# Altium schematic sheet LSM_MACININO_00.SchDoc(LSM_MACININO_00)
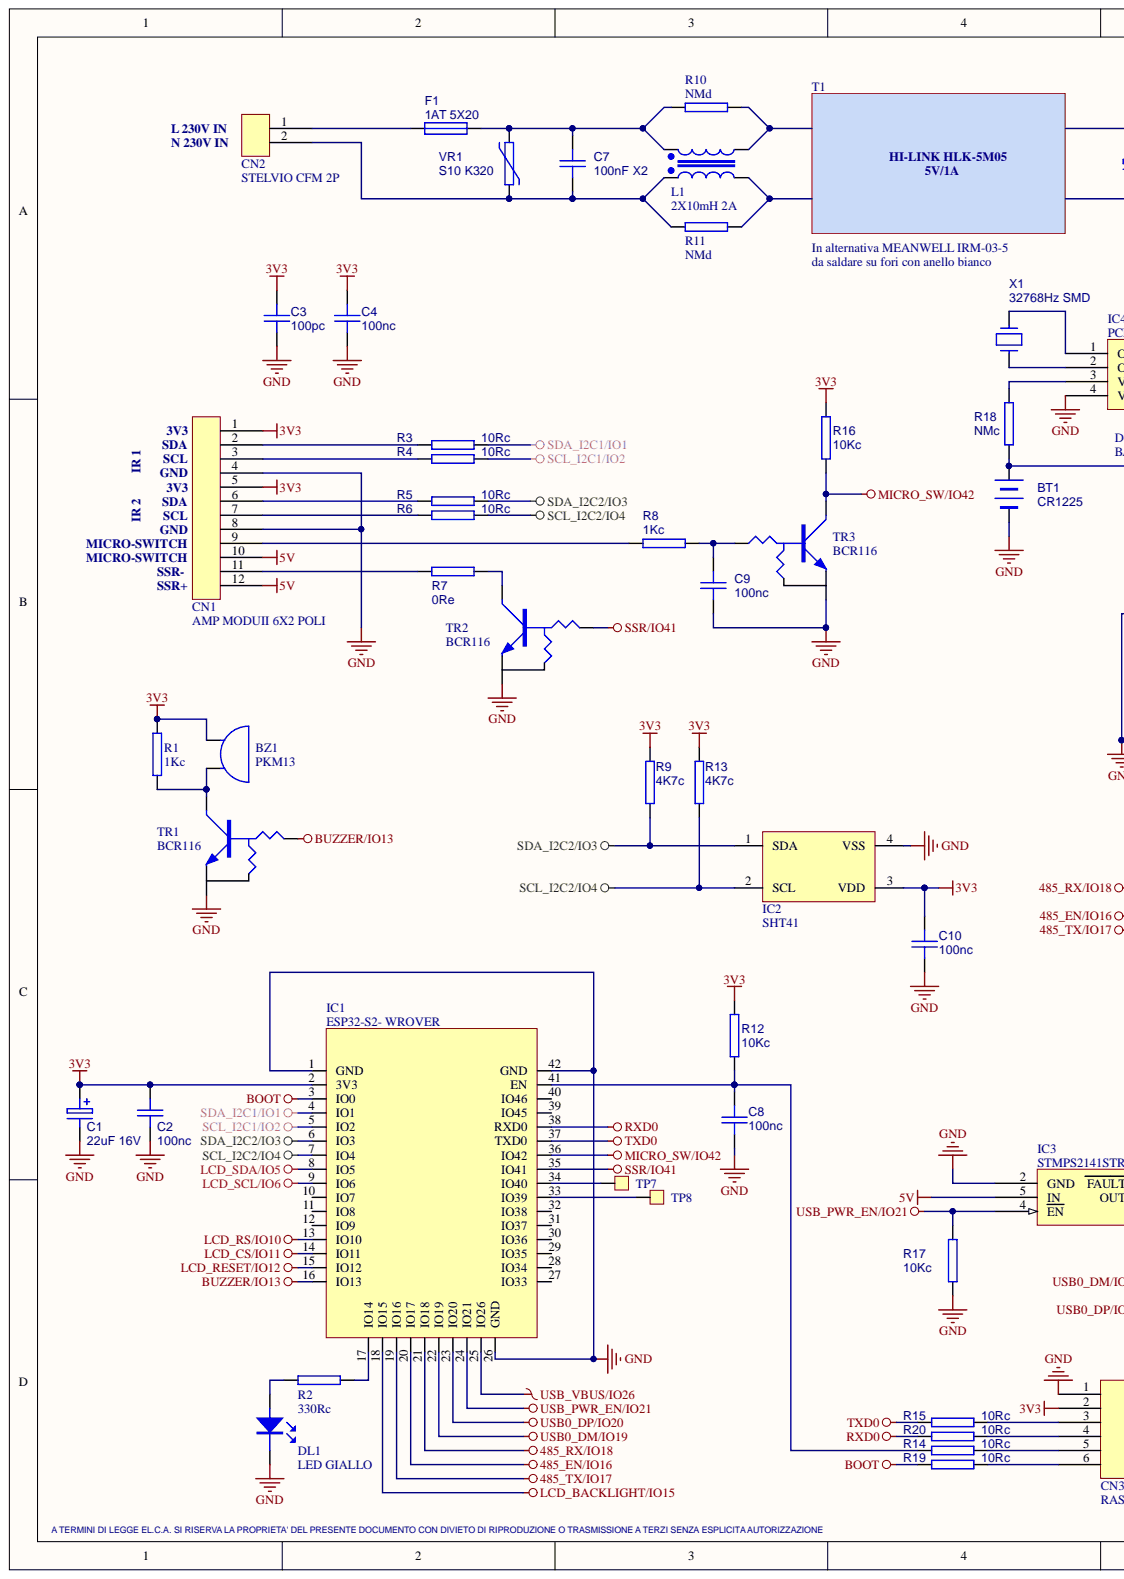
[diagram p0: LSM_MACININO_00.SchDoc, left]
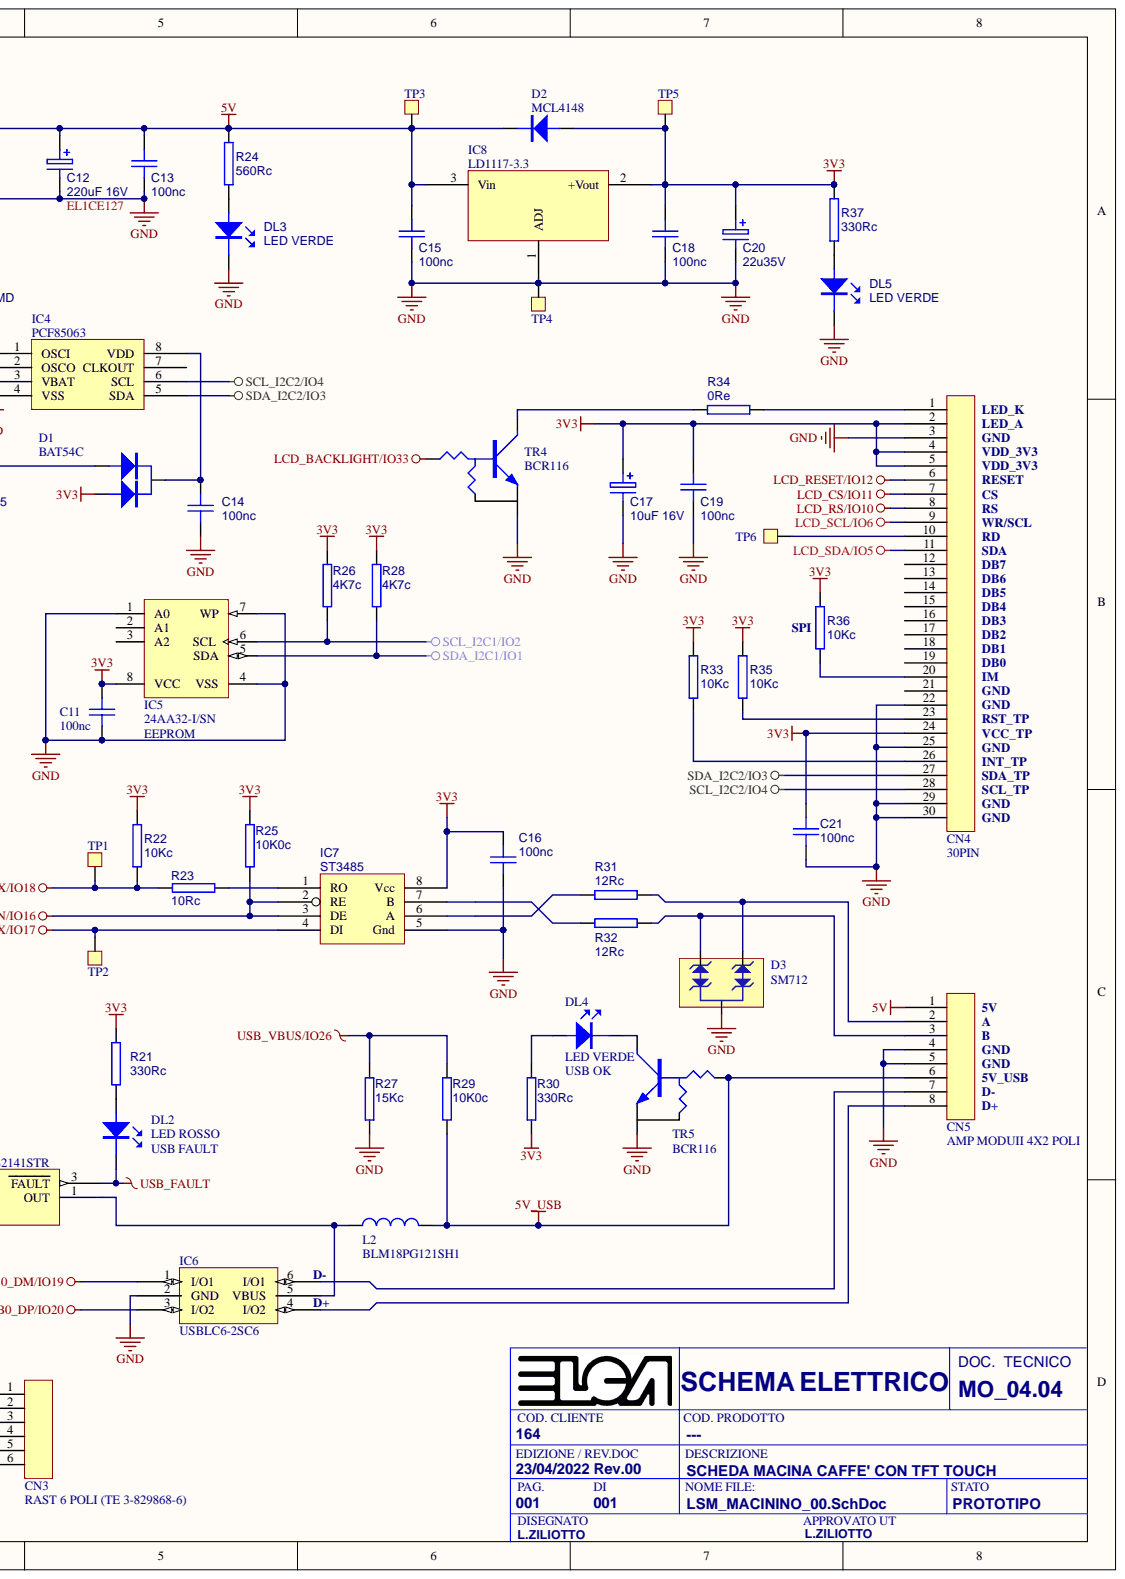
[diagram p1: LSM_MACININO_00.SchDoc, right]

## Parts
BT1  CR1225  [PORTABATTERIA 1225]
BZ1  PKM13  [PKM13]
C1  22uF 16V  [CAP50X]
C2  100nc  [0603]
C3  100pc  [0603]
C4  100nc  [0603]
C7  100nF X2  [12,7X5,5P10,16]
C8  100nc  [0603]
C9  100nc  [0603]
C10  100nc  [0603]
C11  100nc  [0603]
C12  220uF 16V  [D6,6P2,54]
C13  100nc  [0603]
C14  100nc  [0603]
C15  100nc  [0603]
C16  100nc  [0603]
C17  10uF 16V  [CAP40X]
C18  100nc  [0603]
C19  100nc  [0603]
C20  22u35V  [CAP50X]
C21  100nc  [0603]
CN1  AMP MODUII 6X2 POLI  [AMPMODUII-2x6]
CN2  STELVIO CFM 2P  [CFM P.5,08/V 2V]
CN3  RAST 6 POLI (TE 3-829868-6)  [FLY6]
CN4  30PIN  [FFC/FPC 30V/P0,5]
CN5  AMP MODUII 4X2 POLI  [AMPMODUII-2x4]
D1  BAT54C  [SOT-23]
D2  MCL4148  [MICRO MELF]
D3  SM712  [SOT-23]
DL1  LED GIALLO  [L0603]
DL2  LED ROSSO  [L0603]
DL3  LED VERDE  [L0603]
DL4  LED VERDE  [L0603]
DL5  LED VERDE  [L0603]
F1  1AT 5X20  [MICRO-FUSIBILE]
IC1  ESP32-S2- WROVER  [ESP32-S2-WROVER]
IC2  SHT41  [DFN_SHT41]
IC3  STMPS2141STR  [SOT-23-5]
IC4  PCF85063  [SOT1069]
IC5  24AA32-I/SN  ComponentLink1Description: EEPROM  [SO-8]
IC6  USBLC6-2SC6  [SOT-23-6]
IC7  ST3485  [SO-8]
IC8  LD1117-3.3  [SOT-223]
L1  2X10mH 2A  [IND. TENGDA TDS1515]
L2  BLM18PG121SH1  [0603]
R1  1Kc  [0603]
R2  330Rc  [0603]
R3  10Rc  [0603]
R4  10Rc  [0603]
R5  10Rc  [0603]
R6  10Rc  [0603]
R7  0Re  [1210]
R8  1Kc  [0603]
R9  4K7c  [0603]
R10  NMd  [0805]
R11  NMd  [0805]
R12  10Kc  [0603]
R13  4K7c  [0603]
R14  10Rc  [0603]
R15  10Rc  [0603]
R16  10Kc  [0603]
R17  10Kc  [0603]
R18  NMc  [0603]
R19  10Rc  [0603]
R20  10Rc  [0603]
R21  330Rc  [0603]
R22  10Kc  [0603]
R23  10Rc  [0603]
R24  560Rc  [0603]
R25  10K0c  [0603]
R26  4K7c  [0603]
R27  15Kc  [0603]
R28  4K7c  [0603]
R29  10K0c  [0603]
R30  330Rc  [0603]
R31  12Rc  [0603]
R32  12Rc  [0603]
R33  10Kc  [0603]
R34  0Re  [1210]
R35  10Kc  [0603]
R36  10Kc  [0603]
R37  330Rc  [0603]
TP1  (no value)  [TESTPOINT]
TP2  (no value)  [TESTPOINT]
TP3  (no value)  [TESTPOINT]
TP4  (no value)  [TESTPOINT]
TP5  (no value)  [TESTPOINT]
TP6  (no value)  [TESTPOINT]
TP7  (no value)  [TESTPOINT]
TP8  (no value)  [TESTPOINT]
TR1  BCR116  [SOT-23]
TR2  BCR116  [SOT-23]
TR3  BCR116  [SOT-23]
TR4  BCR116  [SOT-23]
TR5  BCR116  [SOT-23]
VR1  S10 K320  [VARIST. D10 P7,5]
X1  32768Hz SMD  [XTAL 4PAD 3,5X8,7]

## Nets
3V3: BZ1.1 C1.1 C2.1 C3.1 C4.1 C10.1 C11.2 C16.1 C17.1 C18.1 C19.1 C20.1 C21.2 CN1.1 CN1.5 CN3.2 CN4.2 CN4.4 CN4.5 CN4.24 D1.2 D2.2 IC1.2(3V3) IC2.3(VDD) IC5.8(VCC) IC7.8(Vcc) IC8.2 R1.1 R9.2 R12.1 R13.2 R16.2 R21.2 R22.2 R25.2 R26.2 R28.2 R30.2 R33.2 R35.2 R36.2 R37.2 TP5.1
5V: C12.1 C13.1 C15.1 CN1.10 CN1.12 CN5.1 D2.1 IC3.5(IN) IC8.3 R24.2 TP3.1
5V_USB: CN5.6 L2.2 R29.2 TR5.1
485_EN/IO16: IC1.20(IO17) IC7.2(RE) IC7.3(DE) R25.1
485_RX/IO18: IC1.21(IO18) R22.1 R23.2 TP1.1
485_TX/IO17: IC1.19(IO16) IC7.4(DI) TP2.1
BOOT: IC1.3(IO0) R19.1
BUZZER/IO13: IC1.16(IO13) TR1.1
GND: BT1.- C1.2 C2.2 C3.2 C4.2 C8.2 C9.2 C10.2 C11.1 C12.2 C13.2 C14.2 C15.2 C16.2 C17.2 C18.2 C19.2 C20.2 C21.1 CN1.4 CN1.8 CN3.1 CN4.3 CN4.22 CN4.25 CN4.29 CN4.30 CN5.4 CN5.5 D3.3 DL1.1 DL3.1 DL5.1 IC1.1(GND) IC1.26(GND) IC1.42(GND) IC2.4(VSS) IC3.2(GND) IC4.4(VSS) IC5.1(A0) IC5.4(VSS) IC5.7(WP) IC6.2(GND) IC7.5(Gnd) IC8.1 R17.2 R27.2 TP4.1 TR1.2 TR2.2 TR3.2 TR4.2 TR5.2
LCD_BACKLIGHT/IO15: IC1.18(IO15)
LCD_BACKLIGHT/IO33: TR4.1
LCD_CS/IO11: CN4.7 IC1.14(IO11)
LCD_RESET/IO12: CN4.6 IC1.15(IO12)
LCD_RS/IO10: CN4.8 IC1.13(IO10)
LCD_SCL/IO6: CN4.9 IC1.9(IO6)
LCD_SDA/IO5: CN4.11 IC1.8(IO5)
MICRO_SW/IO42: IC1.36(IO42) R16.1 TR3.3
RXD0: IC1.38(RXD0) R20.1
SCL_I2C1/IO2: IC1.5(IO2) IC5.6(SCL) R4.1 R26.1
SCL_I2C2/IO4: CN4.28 IC1.7(IO4) IC2.2(SCL) IC4.6(SCL) R6.1 R13.1
SDA_I2C1/IO1: IC1.4(IO1) IC5.5(SDA) R3.1 R28.1
SDA_I2C2/IO3: CN4.27 IC1.6(IO3) IC2.1(SDA) IC4.5(SDA) R5.1 R9.1
SSR/IO41: IC1.35(IO41) TR2.1
TXD0: IC1.37(TXD0) R15.1
USB0_DM/IO19: IC1.22(IO19) IC6.1(I/O1)
USB0_DP/IO20: IC1.23(IO20) IC6.3(I/O2)
USB_FAULT: DL2.1 IC3.3(FAULT)
USB_PWR_EN/IO21: IC1.24(IO21) IC3.4(EN) R17.1
USB_VBUS/IO26: IC1.25(IO26) R27.1 R29.1
NetBT1_+: BT1.+ D1.1 R18.2
NetBZ1_2: BZ1.2 R1.2 TR1.3
NetC7_1: C7.1 F1.2 L1.1 R10.2 VR1.2
NetC7_2: C7.2 CN2.2 L1.2 R11.2 VR1.1
NetC8_1: C8.1 IC1.41(EN) R12.2 R14.1
NetC9_1: C9.1 R8.1 TR3.1
NetC14_1: C14.1 D1.3 IC4.8(VDD)
NetCN1_2: CN1.2 R3.2
NetCN1_3: CN1.3 R4.2
NetCN1_6: CN1.6 R5.2
NetCN1_7: CN1.7 R6.2
NetCN1_9: CN1.9 R8.2
NetCN1_11: CN1.11 R7.1
NetCN2_1: CN2.1 F1.1
NetCN3_3: CN3.3 R15.2
NetCN3_4: CN3.4 R20.2
NetCN3_5: CN3.5 R14.2
NetCN3_6: CN3.6 R19.2
NetCN4_1: CN4.1 R34.2
NetCN4_10: CN4.10 TP6.1
NetCN4_20: CN4.20 R36.1
NetCN4_23: CN4.23 R35.1
NetCN4_26: CN4.26 R33.1
NetCN5_2: CN5.2 D3.1 R31.1
NetCN5_3: CN5.3 D3.2 R32.1
NetCN5_7: CN5.7 IC6.6(I/O1)
NetCN5_8: CN5.8 IC6.4(I/O2)
NetDL1_2: DL1.2 R2.2
NetDL2_2: DL2.2 R21.1
NetDL3_2: DL3.2 R24.1
NetDL4_1: DL4.1 TR5.3
NetDL4_2: DL4.2 R30.1
NetDL5_2: DL5.2 R37.1
NetIC1_17: IC1.17(IO14) R2.1
NetIC1_33: IC1.33(IO39) TP8.1
NetIC1_34: IC1.34(IO40) TP7.1
NetIC3_1: IC3.1(OUT) IC6.5(VBUS) L2.1
NetIC4_1: IC4.1(OSCI) X1.2
NetIC4_2: IC4.2(OSCO) X1.1
NetIC4_3: IC4.3(VBAT) R18.1
NetIC7_1: IC7.1(RO) R23.1
NetIC7_6: IC7.6(A) R31.2
NetIC7_7: IC7.7(B) R32.2
NetL1_3: L1.3 R11.1
NetL1_4: L1.4 R10.1
NetR7_2: R7.2 TR2.3
NetR34_1: R34.1 TR4.3
N00041:

Not connected: CN4 12 13 14 15 16 17 18 19 21; IC1 10(IO7) 11(IO8) 12(IO9) 27(IO33) 28(IO34) 29(IO35) 30(IO36) 31(IO37) 32(IO38) 39(IO45) 40(IO46); IC4 7(CLKOUT); IC5 2(A1) 3(A2)

## Library data of the parts
BT1 = BATTERY: Part Field 1: BATTERIA LITIO 3V CR2032; Part Field 2: ZBATCR2032; Component Kind: Standard
BZ1 = BUZZER: Component Kind: Standard; Library Name: SCHEMATICI.lib
C1 = ELECTRO1: TOLLERANZA: 10%; LAYER: TOP; DESCRIZIONE: COND. TANTALIO 10uF 10V X7R; CODICE ELCA: EL2CT003; Component Kind: Standard
C2 = CAP: TOLLERANZA: 10% X7R; LAYER: TOP; DESCRIZIONE: COND. CERAMICO 100nF 50V X7R; CODICE ELCA: EL2CC013; Component Kind: Standard
C3 C4 C8-C10 C13 C19 C21 = CAP: Part Field 1: CERAMICO 10nF SMD 0805; Part Field 2: ZCC080510NSMD; Component Kind: Standard
C7 C11 C14 = CAP: Component Kind: Standard
  C11: Library Name: SCHEMATICI.lib
C12 C17 = ELECTRO1: Component Kind: Standard; Library Name: SCHEMATICI.lib
C15 C16 C18 = CAP: Part Field 1: CER. 100nF 50V Y5V 0805; Part Field 2: ZCC0805100NSMD; Component Kind: Standard
C20 = ELECTRO1: Part Field 1: ELET. 5X5,5 47MF 16V SMD; Part Field 2: ZCES5X5,547M16V; Component Kind: Standard
CN1 = 12PIN: Component Kind: Standard; Library Name: SCHEMATICI.lib
CN2 = 2PIN: Component Kind: Standard; Library Name: SCHEMATICI.lib
CN3 = 6PIN: Component Kind: Standard; Library Name: SCHEMATICI.lib
CN5 = 8PIN: Component Kind: Standard; Library Name: SCHEMATICI.lib
D1 = DIODE TWIN CK: Component Kind: Standard; Library Name: SCHEMATICI.lib
D2 = DIODE: Component Kind: Standard; Library Name: SCHEMATICI.lib
D3 = DIODO SM712: Component Kind: Standard; Library Name: SCHEMATICI.lib
DL1 = LED: LAYER: TOP; DESCRIZIONE: DIODO LED ROSSO 0805; CODICE ELCA: EL2LE012; Component Kind: Standard; Library Name: SCHEMATICI.lib
DL2-DL5 = LED: Component Kind: Standard
  DL2: : USB FAULT
  DL4: : USB OK
  DL2 DL4: Library Name: Apdevice.lib
  DL3 DL5: Library Name: SCHEMATICI.lib
F1 = FUSE1: Component Kind: Standard
IC3 = STMPS2141STR: Enhanced single channel power switches; Manuf_1: STM; Ref St: 9159003; Provided: ST; ECCN_US/EU: EAR99/NEC; Order: STMPS2141STR; Manuf_Part_1: STMPS2141STR; Library Name: MCD_TOOLS.SchLib; Distrib: ST; Component Kind: Standard
IC4 = PCF85: Library Name: MICRO.Lib; Component Kind: Standard
IC5 = 24AA01-I/MS: 1Kbit, 400kHz, 1.8V, I2C Serial EEPROM, 8-Pin MSOP, Industrial Temperature; Supplier Part Number 3: 92C6791; Supplier Part Number 2: 1331265; Supplier Part Number 1: 24AA01-I/MS-ND; Supplier 3: Newark; Supplier 2: Farnell; Supplier 1: Digi-Key; PackageVersion: revBB, Aug-2009; PackageReference: MSOP-MS8; PackageDescription: 8-Lead Plastic Micro Small Outline Package (MS) [MSOP]; Library Name: Microchip Memory I2C Serial EEPROM.IntLib; FirstPublished: 29-Jan-2009; DatasheetVersion: revJ, Jan-2009; ComponentLink3URL: http://www.microchip.com/; ComponentLink3Description: Microchip.com Home; ComponentLink2URL: http://www.microchip.com/stellent/groups/techpub_sg/documents/packagingspec/en012702.pdf; ComponentLink2Description: Packaging Specification; ComponentLink1URL: http://ww1.microchip.com/downloads/en/DeviceDoc/21711J.pdf; Component Kind: Standard; Publisher: Altium Limited
IC7 = MAX485E: Part Field 1: INTEG. DS75176BM SMD; Part Field 2: ZICSMDDS75176BM; Component Kind: Standard
IC8 = LM117H: Component Kind: Standard; Library Name: VOLT. REG.lib
L1 = INDUCTOR5: Component Kind: Standard; Library Name: SCHEMATICI.lib
L2 = BEAD_120R_2A_0603: Bead,120R,2A,0603,BLM18PG121SN1D,MURATA; Manuf_Part_3: FCM1608KF-601T03; Manuf_Part_2: MPZ1608S300A; Manuf_3: Tai-tech; Manuf_2: TDK; Value: 100uH; Description_3: Bead,600R,350mA,0603,FCM1608KF-601T03,Tai-tech; Description_2: Bead,30R,5A,0603,MPZ1608S300A,TDK; Revision: July-2002: Re-released for DXP Platform.; Manuf_Part_1: BLM18PG121SN1D; Manuf_1: MURATA; Library Name: MCD_TOOLS.SchLib; ECCN_US/EU: n/a; Component Kind: Standard
R1 R7 R8 R22 R23 R25 R33-R36 = RES2: Component Kind: Standard
R2 = RES2: TOLLERANZA: 5%; LAYER: TOP; DESCRIZIONE: RESIST. 330  Ohm SMD 0603; CODICE ELCA: EL2RE122; Component Kind: Standard
R3-R6 R9 R13 R16 R24 R26 R28 R37 = RES2: Part Field 1: RESIST. 100 OHM SMD 0805; Part Field 2: ZRE0805100ESMD; Component Kind: Standard
R10 R11 = RES2: TOLLERANZA: 5%; LAYER: TOP; DESCRIZIONE: RESIST. 330 Ohm SMD 0603; CODICE ELCA: EL2RE122; Component Kind: Standard
R12 = RES2: TOLLERANZA: 5%; LAYER: TOP; DESCRIZIONE: RESIST. 1K Ohm SMD 0603; CODICE ELCA: EL2RE077; Component Kind: Standard
R14 R15 R19 R20 = RES2: Part Field 1: RESIST. 8,2K SMD 0805; Part Field 2: ZRE08058K2SMD; Component Kind: Standard
R17 R27 R29 = RES2: Part Field 1: RESIST. 330K SMD 0805; Part Field 2: ZRE0805330KSMD; Component Kind: Standard
R18 = RES2: Part Field 1: RESIST. 10K SMD 0805; Part Field 2: ZRE080510KSMD; Component Kind: Standard
R21 R30 = RES2: Part Field 1: RESIST. 11K 1% SMD 0805; Part Field 2: ZRE080511KSM1; Component Kind: Standard
R31 R32 = RES2: Part Field 1: RESIST. 12 OHM SMD 1206; Part Field 2: ZRE120612ESMD; Component Kind: Standard
TP1-TP8 = CON1: Component Kind: Standard; Library Name: SCHEMATICI.lib
TR1-TR5 = NPN_DIG: Component Kind: Standard; Library Name: SCHEMATICI.lib
VR1 = VARISTOR: Part Field 1: VARISTORE S07 K275; Part Field 2: ZSCV07K275; Component Kind: Standard
X1 = CRYSTAL: Part Field 1: QUARZO 32,768 KHZ; Part Field 2: ZON32K; Component Kind: Standard
CN4 = 30PIN: Component Kind: Standard; Library Name: SCHEMATICI.lib
IC1 = ESP32-S2- WROVER: Component Kind: Standard; Library Name: MICRO-INTEGRATI.Lib
IC2 = SHT41: Component Kind: Standard; Library Name: MICRO-INTEGRATI.Lib
IC6 = USBLC6-2SC6: Component Kind: Standard; Library Name: MICRO-INTEGRATI.Lib
